# Altium schematic sheet chipjabbersimplev1.SchDoc
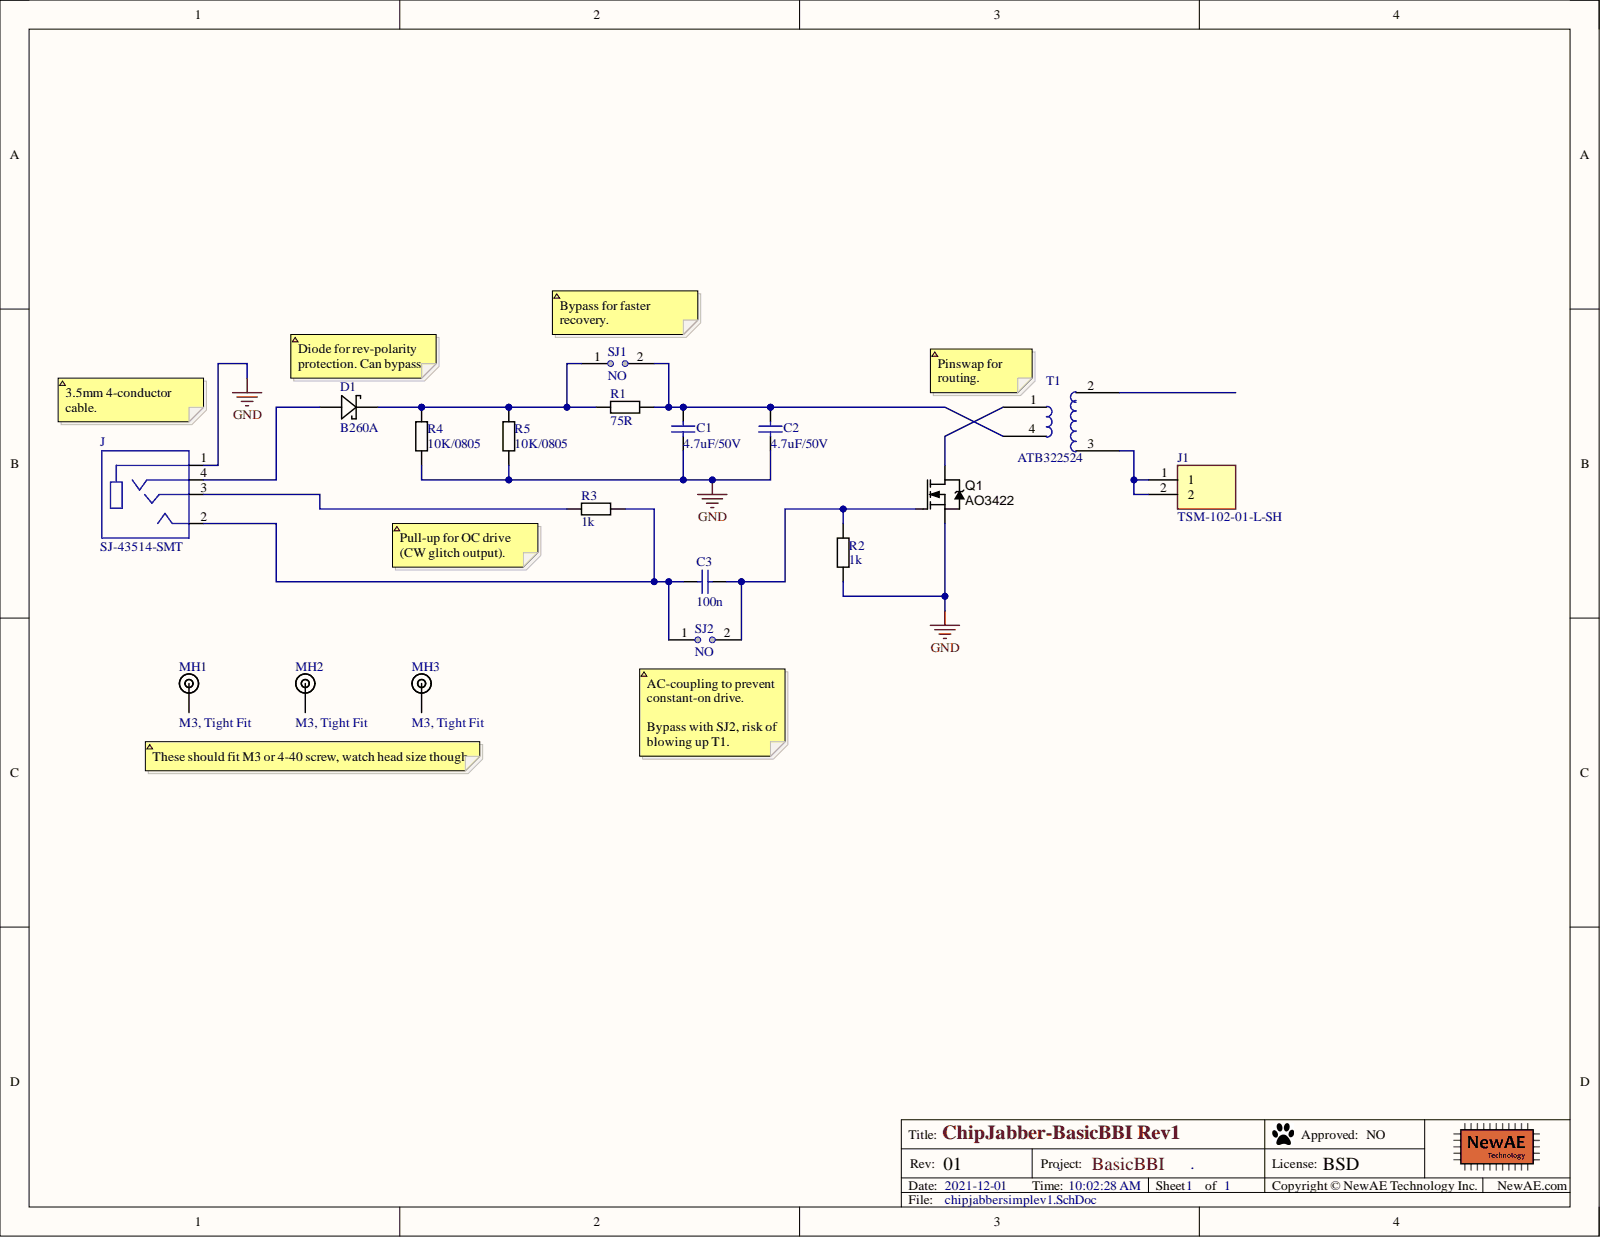
[diagram p0: chipjabbersimplev1.SchDoc]

## Parts
C1  4.7uF/50V  [0805]
C2  4.7uF/50V  [0805]
C3  100n  [0603]
D1  B260A  [DO-214AC]
J  SJ-43514-SMT  [SJ-43514-SMT]
J1  TSM-102-01-L-SH  [TSM-102-YY-ZZZ-SH]
MH1  M3, Tight Fit  [MOUNT_M3_TIGHT]  (mechanical)
MH2  M3, Tight Fit  [MOUNT_M3_TIGHT]  (mechanical)
MH3  M3, Tight Fit  [MOUNT_M3_TIGHT]  (mechanical)
Q1  AO3422  [SOT23]
R1  75R  [0805]
R2  1k  [0603]
R3  1k  [0603]
R4  10K/0805  [0805]
R5  10K/0805  [0805]
SJ1  NO  [SJ_2S_NO]  (no BOM)
SJ2  NO  [SJ_2S_NO]  (no BOM)
T1  ATB322524  [ATB322524]

## Nets
GND: C1.2 C2.2 J.1 Q1.2 R2.2 R4.2 R5.2
NetC1_1: C1.1 C2.1 R1.2 SJ1.2 T1.4
NetC3_1: C3.1 J.2 R3.2 SJ2.1
NetC3_2: C3.2 Q1.1 R2.1 SJ2.2
NetD1_A: D1.A J.4
NetD1_K: D1.K R1.1 R4.1 R5.1 SJ1.1
NetJ1_1: J1.1 J1.2 T1.3
NetJ_3: J.3 R3.1
NetQ1_3: Q1.3 T1.1

Not connected: MH1 1; MH2 1; MH3 1; T1 2

## Library data of the parts
C1 C2 = CCHIP-4u7-0805-50V: 4u7, 50V, 0805, Ceramic; Manufacture 1: TDK Corporation; Manufacture Part Number 1: C2012X5R1H475K125AB; Case-Metric: 1608; Component Group: Capacitor; Database Table Name: main; Rated Voltage: 50VDC; Tolerance: 10%; Value: 4.7uF; Case-EIA: 0805; Component Kind: Standard; Component Type: Standard; Library Name: NewAE_AltiumLib.DBLib; Mounting Technology: SMT; Part Number: CCHIP-4u7-0805-50V; Pin Count: 2; RoHS: Yes; Supplier 1: Digi-Key; Supplier Part Number 1: 445-5980-1-ND; Temperature Range: -55C to 85C
C3 = CCHIP-100n-0603: 100n, 0603; Manufacture 1: Kemet; Manufacture Part Number 1: C0603C104K5RACTU; Case-Metric: 1608; Component Group: Capacitor; Database Table Name: main; Rated Voltage: 50VDC; Case-EIA: 0603; Component Kind: Standard; Component Type: Standard; Library Name: NewAE_AltiumLib.DBLib; Mounting Technology: SMT; Part Number: CCHIP-100n-0603; Pin Count: 2; Supplier 1: Digi-Key; Supplier Part Number 1: 399-5089-2-ND; Value: 100n
D1 = DIODE_SCHOTTKY_2A: DIODE SCHOTTKY 60V 2A SMA; Manufacture 1: Diodes Incorporated; Manufacture Part Number 1: B260A-13-F; Database Table Name: main; PP Material Stack: 0; Component Group: Diode; Component Kind: Standard; Component Type: Standard; Library Name: NewAE_AltiumLib.DBLib; Mounting Technology: SMT; Part Number: DIODE_SCHOTTKY_2A; Pin Count: 2; PP Rotation: 0; RoHS: Yes; Supplier 1: Digi-Key; Supplier Part Number 1: B260A-FDICT-ND
J = SJ-43514-SMT: 4 conductor TRS, 3.5mm; Manufacture 1: CUI Devices; Manufacture Part Number 1: SJ-43514-SMT-TR; Component Group: Connector; Database Table Name: main; PP Material Stack: 0; Availability: Unavailable; Component Kind: Standard; Component Type: Standard; Library Name: NewAE_AltiumLib.DBLib; MF: CUI Devices; MP: SJ-43514-SMT; Package: None; Part Number: SJ-43514-SMT; Pin Count: 4; PP Rotation: 0; PP Rotation1: 0; Price: None; SnapEDA_Link: https://www.snapeda.com/parts/SJ-43514-SMT/CUI%20Devices/view-part/511208/?ref=snap; Supplier 1: Digi-Key; Supplier Part Number 1: CP-43514SJCT-ND
J1 = TSM-102-01-L-SH: Connector; Manufacturer_Name: SAMTEC; Arrow Part Number: TSM-102-01-L-SH; Arrow Price/Stock: https://www.arrow.com/en/products/tsm-102-01-l-sh/samtec; Component Kind: Standard; Datasheet Link: http://suddendocs.samtec.com/prints/tsm-1xx-xx-xxx-xx-x-xxx-x-mkt.pdf; Manufacturer_Part_Number: TSM-102-01-L-SH; Mouser Part Number: 200-TSM10201LSH; Mouser Price/Stock: https://www.mouser.co.uk/ProductDetail/Samtec/TSM-102-01-L-SH?qs=0lQeLiL1qyaA4kL5bMFTfA%3D%3D
MH1-MH3 = MOUNT_M3_TIGHT: Machine screw M3 Mounting Hole; Component Group: Mechanical; Database Table Name: main; PP Material Stack: 0; Component Type: Mechanical; Library Name: NewAE_AltiumLib.DBLib; Part Number: MOUNT_M3_TIGHT; Pin Count: 1; PP Rotation: 0
Q1 = DMN3200U-7: MOSFET N-CH 30V 2.2A SOT23-3; Manufacture 1: Diodes Inc.; Database Table Name: main; PP Material Stack: 0; Rated Power: 3A; Component Group: MOSFET; Component Kind: Standard; Component Type: Standard; Library Name: NewAE_AltiumLib.DBLib; Manufacture Part Number 1: DMN3200U-7; Mounting Technology: SMT; Part Number: DMN3200U-7; Pin Count: 3; PP Rotation: 0; Rated Voltage: 30V; RoHS: Yes; Supplier 1: Digi-Key; Supplier Part Number 1: DMN3200U-7DICT-ND
R1 R4 R5 = RCHIP-75R-0805: 75R res, 0805, 1%, 1/8W; Manufacture 1: Yageo; Manufacture Part Number 1: RC0805FR-0775RL; Component Group: Resistor; Database Table Name: main; Rated Power: 0.125W; Rated Voltage: 75VDC; Case-EIA: 0805; Component Kind: Standard; Component Type: Standard; Library Name: NewAE_AltiumLib.DBLib; Mounting Technology: SMT; Part Number: RCHIP-75R-0805; Pin Count: 2; Supplier 1: Digi-Key; Supplier Part Number 1: 311-75.0CRCT-ND; Temperature Range: -55C to 155C; Tolerance: 1%; Value: 75R
R2 R3 = RCHIP-1K-0603: 1k res, 0603, 1%, 1/10W; Manufacture 1: Yageo; Manufacture Part Number 1: RC0603FR-071KL; Case-Metric: 1608; Component Group: Resistor; Database Table Name: main; Rated Power: 0.1W; Rated Voltage: 75VDC; Case-EIA: 0603; Component Kind: Standard; Component Type: Standard; Library Name: NewAE_AltiumLib.DBLib; Mounting Technology: SMT; Part Number: RCHIP-1K-0603; Pin Count: 2; Supplier 1: Digi-Key; Supplier Part Number 1: 311-1.00KHRCT-ND; Temperature Range: -55C to 155C; Tolerance: 1%; Value: 1k
SJ1 SJ2 = SJ_2S_NO: Solder Jumper, NO; Component Group: PCB Feature; Database Table Name: main; Component Type: Standard (No BOM); Library Name: NewAE_AltiumLib.DBLib; Mounting Technology: COPPER; Part Number: SJ_2S_NO; Pin Count: 2
T1 = ATB322524_PROTO: HV Transformer 1:10, Small; Manufacture 1: TDK; Component Group: Inductor; Database Table Name: main; Component Kind: Standard; Component Type: Standard; Library Name: NewAE_AltiumLib.DBLib; Manufacture Part Number 1: ATB322524; Mounting Technology: SMT; Part Number: ATB322524_PROTO; Pin Count: 4; RoHS: Yes; Supplier 1: Mouser
